# Altium schematic sheet 步兵主控_V1.0 .SchDoc("步兵主控_V1.0 ")
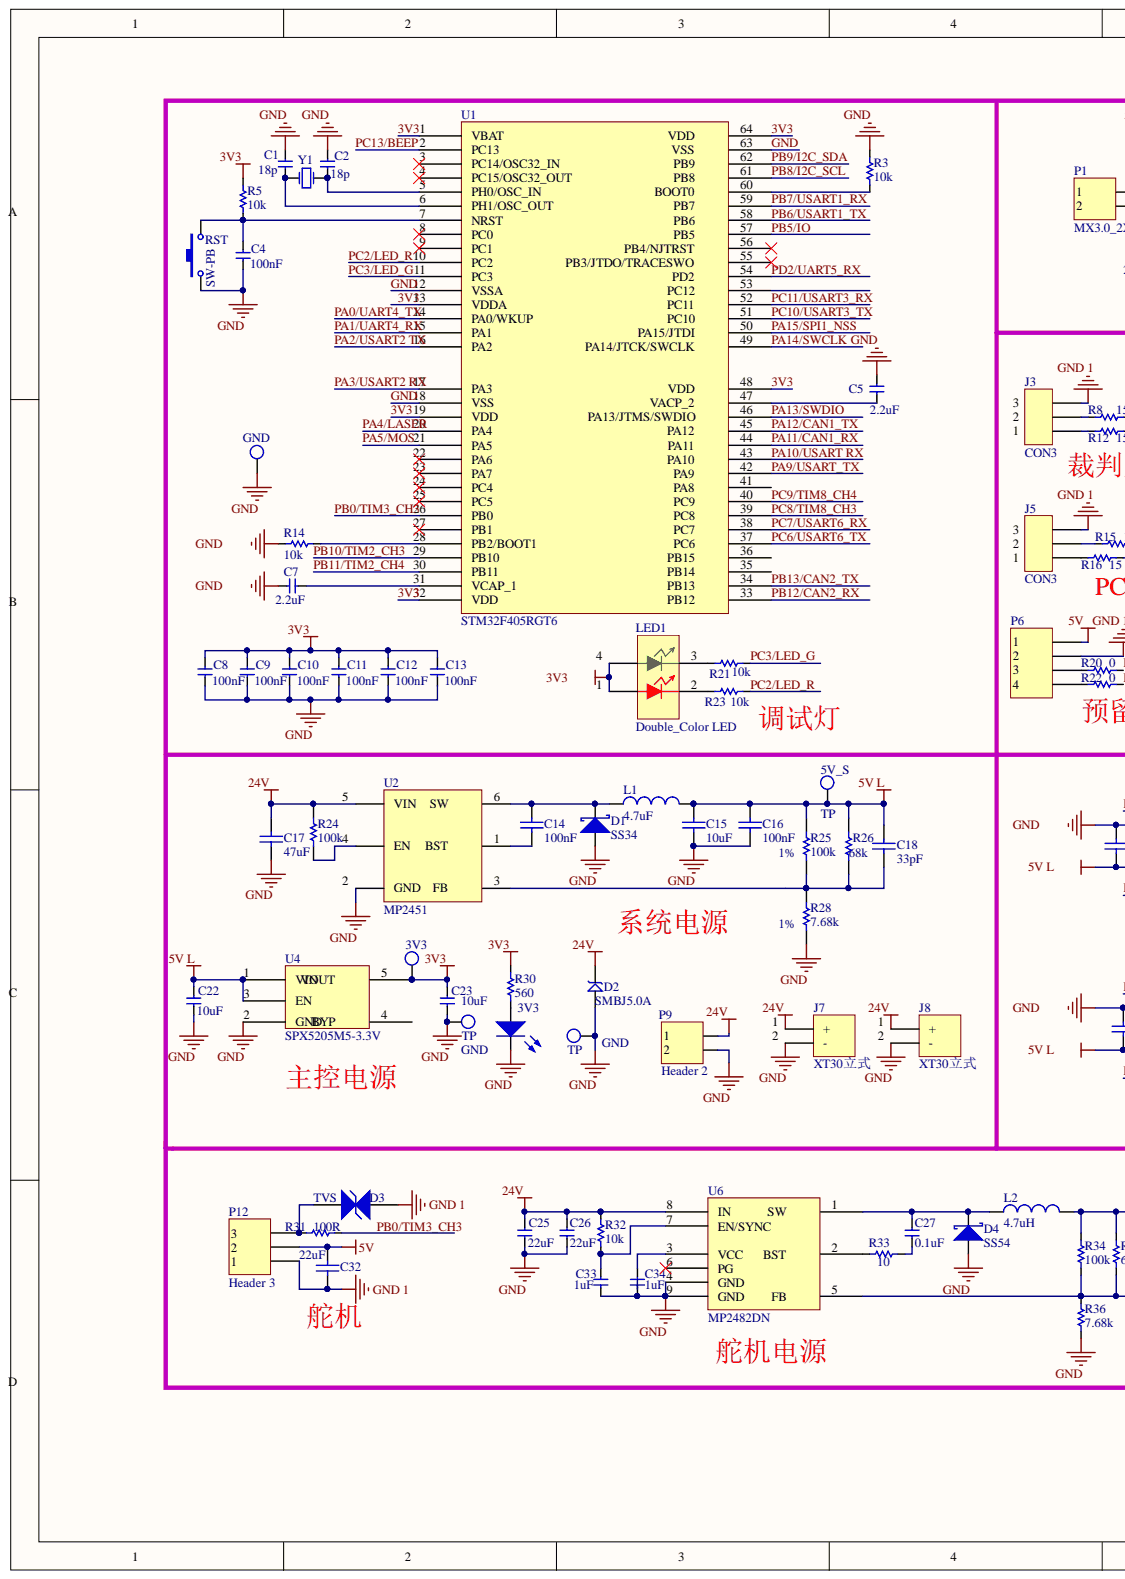
[diagram p0: 步兵主控_V1.0 .SchDoc, left]
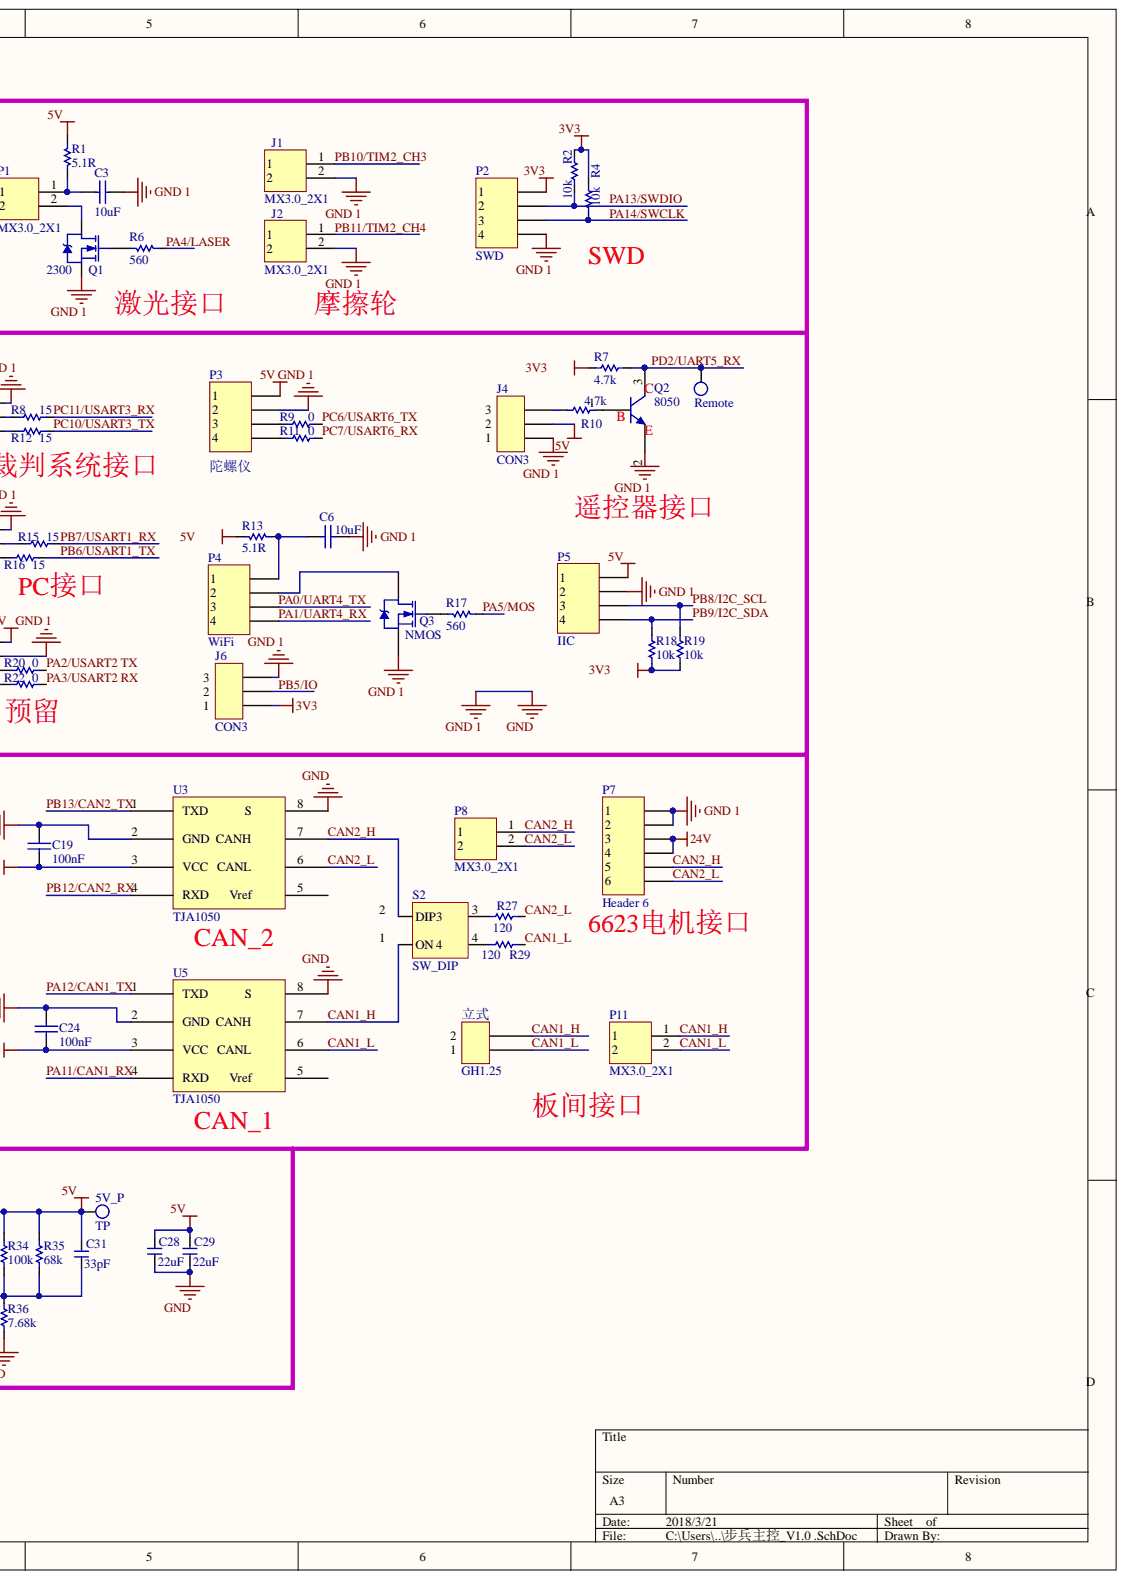
[diagram p1: 步兵主控_V1.0 .SchDoc, right]

## Parts
3V3  TP  [TP_SMT]  (value not drawn)
3V3  LED_RED  [0603LED_B]  (value not drawn)
5V_P  TP  [TP_SMT]
5V_S  TP  [TP_SMT]
C1  18p  [0603C]
C2  18p  [0603C]
C3  10uF  [0603C]
C4  100nF  [0603C]
C5  2.2uF  [0603C]
C6  10uF  [0603C]
C7  2.2uF  [0603C]
C8  100nF  [0603C]
C9  100nF  [0603C]
C10  100nF  [0603C]
C11  100nF  [0603C]
C12  100nF  [0603C]
C13  100nF  [0603C]
C14  value 100nF  [0603C]  (hidden comment: 1uF/0603/50V)
C15  value 10uF  [0805C]  (hidden comment: 1uF/0603/50V)
C16  value 100nF  [0603C]  (hidden comment: 1uF/0603/50V)
C17  value 47uF  [1206C]  (hidden comment: 1uF/0603/50V)
C18  value 33pF  [0603C]  (hidden comment: 1uF/0603/50V)
C19  100nF  [0603C]
C22  10uF  [0603C]
C23  10uF  [0603C]
C24  100nF  [0603C]
C25  22uF  [1206]
C26  22uF  [1206]
C27  0.1uF  [0603C]
C28  22uF  [0805]
C29  22uF  [0805]
C31  33pF  [0603C]
C32  value 22uF  [0805]  (hidden comment: 1uF/0603/50V)
C33  1uF  [0603C]
C34  1uF  [0603C]
D1  SS34  [SOD-323]
D2  SMBJ5.0A  [SMB/DO-214AA]
D3  TVS  [SOD-323]
D4  SS54  [SMA/DO-214AC]
GND  TP  [TP_VIA]
GND  TP  [TP_VIA]
GND  TP  [TP_VIA]
J1  MX3.0_2X1  [HY2.00MM 2X1_立式]
J2  MX3.0_2X1  [HY2.00MM 2X1_立式]
J3  CON3  [HY2.00MM 3x1立式]
J4  CON3  [HY2.00MM 3x1立式]
J5  CON3  [HY2.00MM 3x1立式]
J6  CON3  [HY2.00MM 3x1立式]
J7  XT30立式  [XT30立式]
J8  XT30立式  [XT30立式]
L1  value 4.7uF  [0420L]  (hidden comment: 10mH/0402)
L2  value 4.7uH  [0630L]  (hidden comment: Inductor)
LED1  Double_Color LED  [0805LEDX2]
P1  MX3.0_2X1  [HY2.00MM 2X1]
P2  SWD  [HEADER 4x1]
P3  陀螺仪  [HY2.00MM 4X1立式]
P4  WiFi  [HY2.00MM 4X1立式]
P5  IIC  [HY2.00MM 4X1立式]
P6  (no value)  [HY2.00MM 4X1立式]
P7  Header 6  [HX20018-WA(PA)]
P8  MX3.0_2X1  [MX3.0MM 2X1AW]
P9  Header 2  [XT30PW-Male]
P11  MX3.0_2X1  [MX3.0MM 2X1AW]
P12  Header 3  [HY2.00MM 3x1立式]
Q1  2300  [SOT-23-3]
Q2  8050  [SOT-23-3]
Q3  NMOS  [SOT-23-3]
R1  5.1R  [0603R]
R2  10k  [0603R]
R3  10k  [0603R]
R4  10k  [0603R]
R5  10k  [0603R]
R6  560  [0603R]
R7  4.7k  [0603R]
R8  15  [0603R]
R9  0  [0603R]
R10  4.7k  [0603R]
R11  0  [0603R]
R12  15  [0603R]
R13  5.1R  [0603R]
R14  10k  [0603R]
R15  15  [0603R]
R16  15  [0603R]
R17  560  [0603R]
R18  10k  [0603R]
R19  10k  [0603R]
R20  0  [0603R]
R21  10k  [0603R]
R22  0  [0603R]
R23  10k  [0603R]
R24  value 100k  [0603R]  (hidden comment: Res1)
R25  1%  value 100k  [0603R]
R26  value 68k  [0603R]  (hidden comment: Res1)
R27  120  [0603R]
R28  1%  value 7.68k  [0603R]
R29  120  [0603R]
R30  560  [0603R]
R31  100R  [0603R]
R32  10k  [0603R]
R33  10  [0603R]
R34  100k  [0603R]
R35  68k  [0603R]
R36  7.68k  [0603R]
Remote  TP  [TP_SMT]  (value not drawn)
RST  SW-PB  [SW 3mmx4mm]
S2  SW_DIP  [SW_DIP_2X2]
U1  STM32F405RGT6  [LQFP_64]
U2  MP2451  [SOT23-6]
U3  TJA1050  [D8_NoThermal]
U4  SPX5205M5-3.3V  [SOT-23-5 L]
U5  TJA1050  [D8_NoThermal]
U6  MP2482DN  [SOIC8E]
Y1  XTAL  [NX5032GA]  (value not drawn)
立式  GH1.25  [A1254-02AWB]

## Nets
5V: 5V_P.1 C28.2 C29.2 C31.2 C32.2 J4.2 L2.2 P3.1 P5.1 P6.1 P12.2 R1.1 R13.1 R34.2 R35.2
5V L: 5V_S.1 C15.2 C16.2 C18.1 C19.1 C22.2 C24.1 L1.2 R25.2 R26.2 U3.3(VCC) U4.1(VIN) U4.3(EN) U5.3(VCC)
24V: C17.2 C25.2 C26.2 D2.2 J7.1 J8.1 P7.3 P7.4 P9.1 R24.2 R32.2 U2.5(VIN) U6.8(IN)
3V3: 3V3.1 C8.2 C9.2 C10.2 C11.2 C12.2 C13.2 C23.2 J6.1 LED1.1 LED1.4 P2.1 R2.2 R4.2 R5.2 R7.2 R18.2 R19.2 R30.2 U1.1(VBAT) U1.13(VDDA) U1.19(VDD) U1.32(VDD) U1.48 U1.64 U4.5
CAN1_H: P11.1 S2.1(ON) U5.7 立式.2
CAN1_L: P11.2 R29.2 U5.6 立式.1
CAN2_H: P7.5 P8.1 S2.2(DIP) U3.7
CAN2_L: P7.6 P8.2 R27.1 U3.6
GND: 3V3.2 C1.2 C2.1 C3.2 C4.1 C5.1 C6.2 C7.1 C8.1 C9.1 C10.1 C11.1 C12.1 C13.1 C15.1 C16.1 C17.1 C19.2 C22.1 C23.1 C24.2 C25.1 C26.1 C28.1 C29.1 C32.1 C33.2 C34.2 D1.1 D2.1 D3.1 D4.1 GND.1 J1.2 J2.2 J3.3 J4.1 J5.3 J6.3 J7.2 J8.2 P2.4 P3.2 P5.2 P6.2 P7.1 P7.2 P9.2 P12.1 Q1.2 Q2.2 Q3.2 R3.2 R14.1 R28.1 R36.1 RST.1 U1.12(VSSA) U1.18(VSS) U1.63 U2.2(GND) U3.2(GND) U3.8 U4.2(GND) U5.2(GND) U5.8 U6.4(GND) U6.9(GND)
PA0/UART4_TX: P4.3 U1.14(PA0/WKUP)
PA1/UART4_RX: P4.4 U1.15(PA1)
PA2/USART2 TX: R20.2 U1.16(PA2)
PA3/USART2 RX: R22.2 U1.17(PA3)
PA4/LASER: R6.2 U1.20(PA4)
PA5/MOS: R17.2 U1.21(PA5)
PA9/USART_TX: U1.42
PA10/USART RX: U1.43
PA11/CAN1_RX: U1.44 U5.4(RXD)
PA12/CAN1_TX: U1.45 U5.1(TXD)
PA13/SWDIO: P2.2 R2.1 U1.46
PA14/SWCLK: P2.3 R4.1 U1.49
PA15/SPI1_NSS: U1.50
PB0/TIM3_CH3: R31.1 U1.26(PB0)
PB5/IO: J6.2 U1.57
PB6/USART1_TX: R16.2 U1.58
PB7/USART1_RX: R15.2 U1.59
PB8/I2C_SCL: P5.3 R19.1 U1.61
PB9/I2C_SDA: P5.4 R18.1 U1.62
PB10/TIM2_CH3: J1.1 U1.29(PB10)
PB11/TIM2_CH4: J2.1 U1.30(PB11)
PB12/CAN2_RX: U1.33 U3.4(RXD)
PB13/CAN2_TX: U1.34 U3.1(TXD)
PC2/LED_R: R23.1 U1.10(PC2)
PC3/LED_G: R21.1 U1.11(PC3)
PC6/USART6_TX: R9.2 U1.37
PC7/USART6_RX: R11.2 U1.38
PC8/TIM8_CH3: U1.39
PC9/TIM8_CH4: U1.40
PC10/USART3_TX: R12.2 U1.51
PC11/USART3_RX: R8.2 U1.52
PC13/BEEP: U1.2(PC13)
PD2/UART5_RX: Q2.3 R7.1 Remote.1 U1.54
Net3V3_1: 3V3.1 R30.1
NetC1_1: C1.1 U1.6(PH1/OSC_OUT) Y1.1
NetC2_2: C2.2 U1.5(PH0/OSC_IN) Y1.2
NetC3_1: C3.1 P1.1 R1.2
NetC4_2: C4.2 R5.1 RST.2 U1.7(NRST)
NetC5_2: C5.2 U1.47
NetC6_1: C6.1 P4.1 R13.2
NetC7_2: C7.2 U1.31(VCAP_1)
NetC14_1: C14.1 U2.1
NetC14_2: C14.2 D1.2 L1.1 U2.6
NetC18_2: C18.2 R25.1 R26.1 R28.2 U2.3
NetC27_1: C27.1 R33.1
NetC27_2: C27.2 D4.2 L2.1 U6.1
NetC31_1: C31.1 R34.1 R35.1 R36.2 U6.5
NetC33_1: C33.1 R32.1 U6.7(EN/SYNC)
NetC34_1: C34.1 U6.3(VCC)
NetD3_2: D3.2 P12.3 R31.2
NetJ3_1: J3.1 R12.1
NetJ3_2: J3.2 R8.1
NetJ4_3: J4.3 R10.2
NetJ5_1: J5.1 R16.1
NetJ5_2: J5.2 R15.1
NetLED1_2: LED1.2 R23.2
NetLED1_3: LED1.3 R21.2
NetP1_2: P1.2 Q1.3
NetP3_3: P3.3 R9.1
NetP3_4: P3.4 R11.1
NetP4_2: P4.2 Q3.3
NetP6_3: P6.3 R20.1
NetP6_4: P6.4 R22.1
NetQ1_1: Q1.1 R6.1
NetQ2_1: Q2.1 R10.1
NetQ3_1: Q3.1 R17.1
NetR3_1: R3.1 U1.60
NetR14_2: R14.2 U1.28(PB2/BOOT1)
NetR24_1: R24.1 U2.4(EN)
NetR27_2: R27.2 S2.3
NetR29_1: R29.1 S2.4
NetR33_2: R33.2 U6.2
N00010:

Not connected: U1 3(PC14/OSC32_IN) 4(PC15/OSC32_OUT) 8(PC0) 9(PC1) 22(PA6) 23(PA7) 24(PC4) 25(PC5) 27(PB1) 35 36 41 53 55 56; U3 5; U4 4; U5 5; U6 6(PG)

## Library data of the parts
3V3 5V_P 5V_S GND GND GND Remote = TP: Test Point; Component Kind: Standard; Library Name: MyComponents.SchLib
3V3 = LED: Typical RED, GREEN, YELLOW, AMBER GaAs LED; Component Kind: Standard; LatestRevisionDate: 17-Jul-2002; LatestRevisionNote: Re-released for DXP Platform.; Library Name: MyComponents.SchLib; PackageDescription: SM LED; 2 Flat Leads; PackageReference: 3.2X1.6X1.1; PackageVersion: Feb-2002; Published: 8-Jun-2000; Simulation: LED2; Publisher: Altium Limited
C1 C2 C4 C5 C7-C13 C22 C23 C25-C29 C31 C33 C34 = Cap: Component Kind: Standard; Library Name: WolferWu.SchLib
  C1 C2: Value: 18p
  C4 C8-C13: Value: 100nF
  C5 C7: Value: 2.2uF
  C22 C23: Value: 10uF
  C25 C26 C28 C29: Value: 22uF
  C27: Value: 0.1uF
  C31: Value: 33pF
  C33 C34: Value: 1uF
C3 C6 C19 C24 = Cap: Capacitor; Value: 100pF; Component Kind: Standard; LatestRevisionDate: 17-Jul-2002; LatestRevisionNote: Re-released for DXP Platform.; Library Name: MyComponents.SchLib; PackageDescription: Radial Cap, Thru-Hole; 2 Leads; 0.3 in Pin Spacing; PackageReference: RAD-0.3; Published: 8-Jun-2000; Signal Integrity: Cap; Simulation: CAP; Publisher: Altium Limited
C14-C18 C32 = Cap: Capacitor; Component Kind: Standard; LatestRevisionDate: 17-Jul-2002; LatestRevisionNote: Re-released for DXP Platform.; PackageDescription: Radial Cap, Thru-Hole; 2 Leads; 0.3 in Pin Spacing; PackageReference: RAD-0.3; Published: 8-Jun-2000; Signal Integrity: Cap; Simulation: CAP; Publisher: Altium Limited
  C14-C18: Library Name: MyComponents.IntLib
  C32: Library Name: MyComponents.SchLib
D1 D4 = D Schottky: Schottky Diode; Code_JEDEC: DO-214-AA; Component Kind: Standard; LatestRevisionDate: 17-Jul-2002; LatestRevisionNote: Re-released for DXP Platform.; PackageDescription: DO-214-AA/SMB; 2 C-Bend Leads; Body 5.3 x 3.6 mm, inc. leads (LxW); PackageReference: SMB; Published: 8-Jun-2000; Signal Integrity: D Schottky; Simulation: SKYDIODE; Publisher: Altium Limited
  D1: Library Name: MyComponents.IntLib
  D4: Library Name: MyComponents.SchLib
D2 = SMBJ: TVS; Component Kind: Standard; Library Name: MyComponents.SchLib
J7 J8 = XT30: Component Kind: Standard; Library Name: AllSCH.SchLib
L1 L2 = Inductor: Code_IEC: 1005; Code_JEITA: 0402; Component Kind: Standard; Description: Inductor; LatestRevisionDate: 29-May-2009; LatestRevisionNote: IPC-7351 Footprint Added.; PackageDescription: Chip Inductor; PackageReference: 0402-A; Published: 8-Jun-2000; Signal Integrity: Inductor; Simulation: INDUCTOR; Publisher: Altium Limited
  L1: Library Name: MyComponents.IntLib
  L2: Library Name: Miscellaneous Devices.IntLib
P2-P6 = Header 4: Header, 4-Pin; Component Kind: Standard; LatestRevisionDate: 17-Jul-2002; LatestRevisionNote: Re-released for DXP Platform.; Library Name: Miscellaneous Connectors.IntLib; Signal Integrity: Connector; Publisher: Altium Limited
P7 = Header 6: Header, 6-Pin; Component Kind: Standard; LatestRevisionDate: 17-Jul-2002; LatestRevisionNote: Re-released for DXP Platform.; Library Name: Miscellaneous Connectors.IntLib; Signal Integrity: Connector; Publisher: Altium Limited
P9 = Header 2: Header, 2-Pin; Component Kind: Standard; LatestRevisionDate: 17-Jul-2002; LatestRevisionNote: Re-released for DXP Platform.; Library Name: Miscellaneous Connectors.IntLib; Signal Integrity: Connector; Publisher: Altium Limited
P12 = Header 3: Header, 3-Pin; Component Kind: Standard; LatestRevisionDate: 17-Jul-2002; LatestRevisionNote: Re-released for DXP Platform.; Library Name: Miscellaneous Connectors.IntLib; Signal Integrity: Connector; Publisher: Altium Limited
Q1 = NMOS_3p: N-Channel Power MOSFET; Note: Pin 2 is electrically connected to pin 4.; Component Kind: Standard; ComponentLink1Description: Manufacturer Link; ComponentLink1URL: http://www.irf.com/; DatasheetVersion: PD-9.814; LatestRevisionDate: 17-Jul-2002; LatestRevisionNote: Re-released for DXP Platform.; Library Name: MyComponents.SchLib; PackageDescription: TO, Thru-Hole, Vertical, Heatsink Mounted; 3 In-Line Leads; Pitch 2.54 mm; PackageReference: TO-220AB; PackageVersion: Feb-1997; Published: 8-Jun-2000; Simulation: IRF1010; Publisher: Altium Limited
Q2 = 8050(NPN)SMT: NPN 贴片; Code_JEDEC: TO-226-AA; Component Kind: Standard; LatestRevisionDate: 17-Jul-2002; LatestRevisionNote: Re-released for DXP Platform.; Library Name: MyComponents.SchLib; PackageDescription: TO, Flat Index; 3 In-Line, Axial Leads; Body Dia. 4.8mm; Leads 0.55 x 0.51 mm (max); PackageReference: TO-226-AA; Published: 8-Jun-2000; Signal Integrity: NPN; Simulation: NPN; Publisher: Altium Limited
Q3 = NMOS(SOT23): N-Channel Power MOSFET; Note: Pin 2 is electrically connected to pin 4.; Component Kind: Standard; ComponentLink1Description: Manufacturer Link; ComponentLink1URL: http://www.irf.com/; DatasheetVersion: PD-9.814; LatestRevisionDate: 17-Jul-2002; LatestRevisionNote: Re-released for DXP Platform.; Library Name: MyComponents.IntLib; PackageDescription: TO, Thru-Hole, Vertical, Heatsink Mounted; 3 In-Line Leads; Pitch 2.54 mm; PackageReference: TO-220AB; PackageVersion: Feb-1997; Published: 8-Jun-2000; Publisher: Altium Limited
R1 R6 R13 R17 = Res1: Resistor; Value: 120R; Component Kind: Standard; LatestRevisionDate: 17-Jul-2002; LatestRevisionNote: Re-released for DXP Platform.; Library Name: MyComponents.IntLib; PackageDescription: Axial Device, Thru-Hole; 2 Leads; 0.3 in Pin Spacing; PackageReference: AXIAL-0.3; Published: 8-Jun-2000; Signal Integrity: Res; Simulation: RESISTOR; Publisher: Altium Limited
R2 R4 R7-R12 R15 R16 R18-R23 R27 R29-R31 = Res1: Resistor; Value: 1K; Component Kind: Standard; LatestRevisionDate: 17-Jul-2002; LatestRevisionNote: Re-released for DXP Platform.; PackageDescription: Axial Device, Thru-Hole; 2 Leads; 0.3 in Pin Spacing; PackageReference: AXIAL-0.3; Published: 8-Jun-2000; Signal Integrity: Res; Simulation: RESISTOR; Publisher: Altium Limited
  R2 R4 R7-R12 R15 R16 R20-R23 R27 R29-R31: Library Name: MyComponents.SchLib
  R18 R19: Library Name: MyComponents.IntLib
R3 R5 R14 R32-R36 = Res: Component Kind: Standard; Description: Res; Library Name: WolferWu.SchLib
  R3 R5 R14 R32: Vaule: 10k
  R33: Vaule: 10
  R34: Vaule: 100k
  R35: Vaule: 68k
  R36: Vaule: 7.68k
R24-R26 R28 = Res1: Resistor; Component Kind: Standard; LatestRevisionDate: 17-Jul-2002; LatestRevisionNote: Re-released for DXP Platform.; Library Name: Miscellaneous Devices.IntLib; PackageDescription: Axial Device, Thru-Hole; 2 Leads; 0.3 in Pin Spacing; PackageReference: AXIAL-0.3; Published: 8-Jun-2000; Signal Integrity: Res; Simulation: RESISTOR; Publisher: Altium Limited
RST = SW-PB: Switch; Component Kind: Standard; LatestRevisionDate: 17-Jul-2002; LatestRevisionNote: Re-released for DXP Platform.; Library Name: Miscellaneous Devices.IntLib; PackageDescription: Switch; 2 Leads; PackageReference: SPST-2; Published: 24-Mar-1999; Publisher: Altium Limited
U2 = MP2451: 开关电源0.6A; Component Kind: Standard; Library Name: MyComponents.IntLib
U6 = MP2482DN: 开关电源5A; Component Kind: Standard; Library Name: MyComponents.SchLib
Y1 = XTAL: Crystal Oscillator; Component Kind: Standard; LatestRevisionDate: 17-Jul-2002; LatestRevisionNote: Re-released for DXP Platform.; Library Name: MyComponents.SchLib; PackageDescription: Crystal, Thru-Hole; 2 Leads; Body 3.1 x 8.2 mm (Dia.xH); PackageReference: R38; Published: 8-Jun-2000; Simulation: XTAL; Publisher: Altium Limited
D3 = TVS: Component Kind: Standard; Library Name: MyComponents.SchLib
J1 J2 P1 P8 P11 = MX3.0_2X1: Component Kind: Standard; Library Name: MyComponents.SchLib
J3-J6 = CON3: Component Kind: Standard; Library Name: MyComponents.SchLib
LED1 = Double_Color LED: Component Kind: Standard; Library Name: MyComponents.SchLib
S2 = SW_DIP: Component Kind: Standard; Library Name: MyComponents.SchLib
U1 = STM32F405RGT6: Component Kind: Standard; Description: STM32F405RGT6; Library Name: MyComponents.SchLib
U3 U5 = TJA1050: Component Kind: Standard; Library Name: MyComponents.SchLib
U4 = SPX5205M5-3.3V: Component Kind: Standard; Library Name: MyComponents.SchLib
立式 = GH1.25: Component Kind: Standard; Library Name: MyComponents_v2.3.SchLib
